# Altium schematic sheet Sch-QWA17_MCU.SchDoc(Sch-QWA17_MCU)
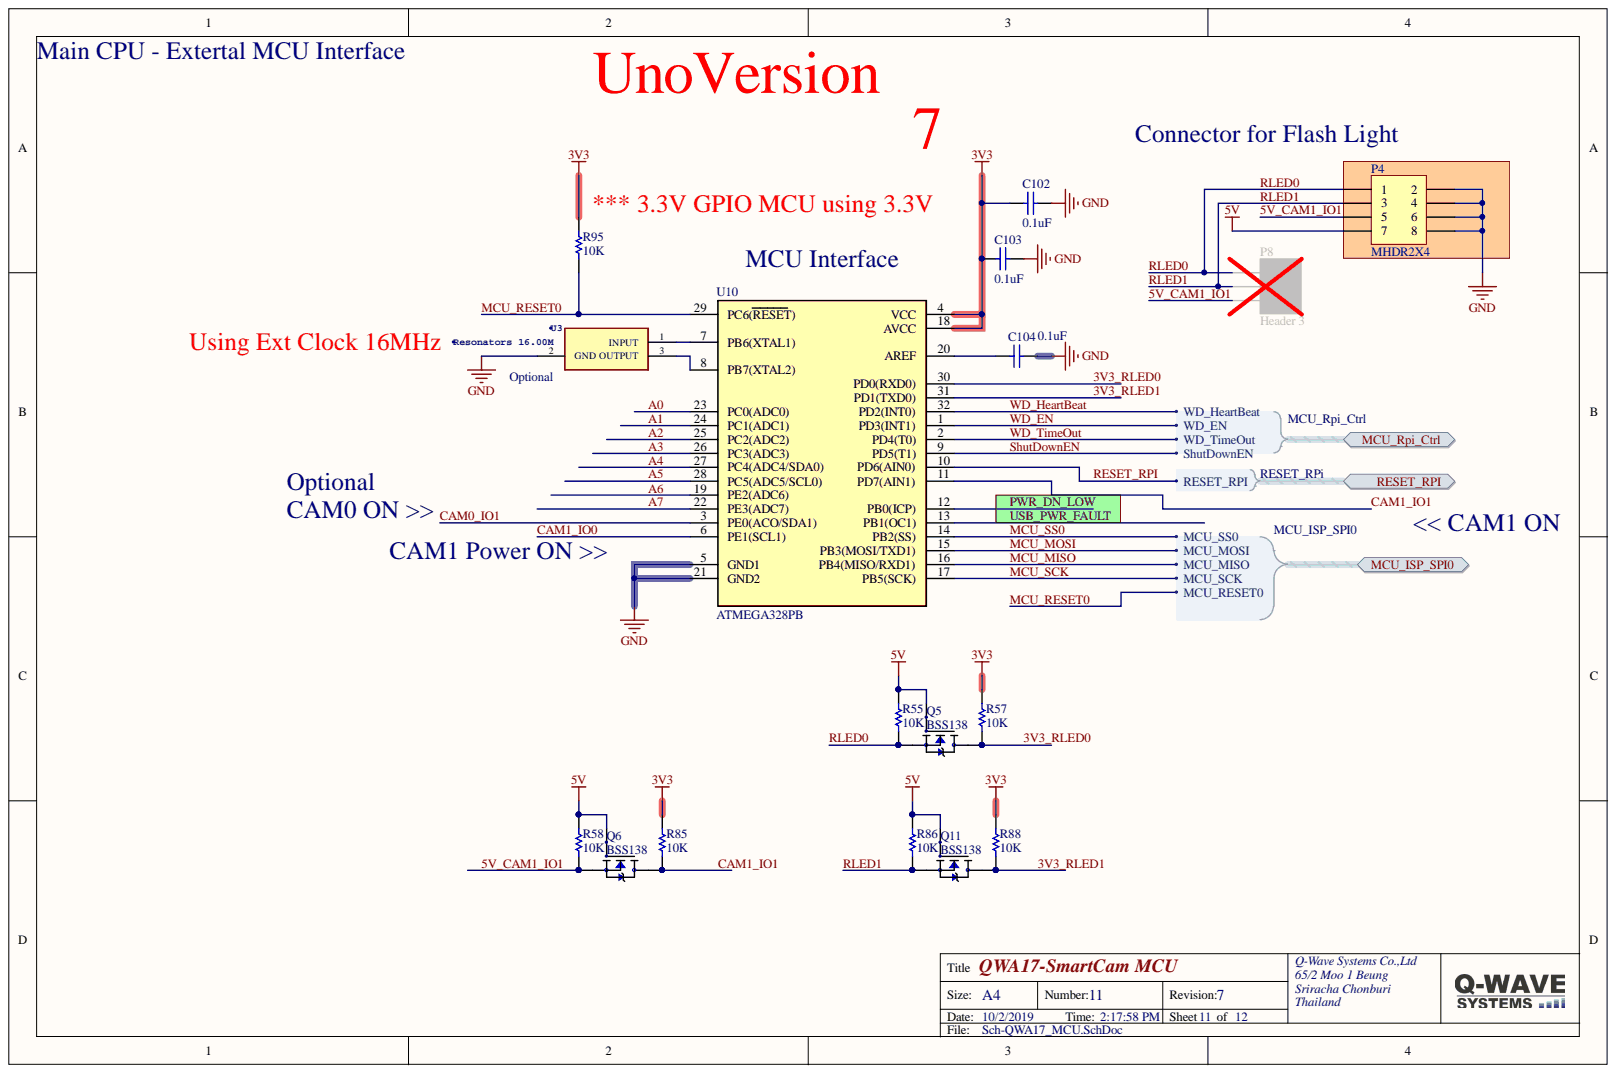
[diagram p0: Sch-QWA17_MCU.SchDoc]

## Parts
C102  0.1uF  [CAP_0603]
C103  0.1uF  [CAP_0603]
C104  0.1uF  [CAP_0603]
P4  MHDR2X4  [HDR2X4_2.54_180]
P8  Header 3  [FCI 06-381-3PIN]
Q5  BSS138  [SOT-23-3]
Q6  BSS138  [SOT-23-3]
Q11  BSS138  [SOT-23-3]
R55  10K  [RES_0603]
R57  10K  [RES_0603]
R58  10K  [RES_0603]
R85  10K  [RES_0603]
R86  10K  [RES_0603]
R88  10K  [RES_0603]
R95  10K  [RES_0603]
U3  Resonators 16.00M  [CSTNE16M0VH3C000R0]
U10  ATMEGA328PB  [LQFP_P0.8_32]

## Ports
MCU_ISP_SPI0, MCU_ISP_SPI0.MCU_MISO, MCU_ISP_SPI0.MCU_MOSI, MCU_ISP_SPI0.MCU_RESET0, MCU_ISP_SPI0.MCU_SCK, MCU_ISP_SPI0.MCU_SS0, MCU_RPI_CTRL, MCU_RPI_CTRL.SHUTDOWNEN, MCU_RPI_CTRL.WD_EN, MCU_RPI_CTRL.WD_HEARTBEAT, MCU_RPI_CTRL.WD_TIMEOUT, RESET_RPI, RESET_RPI.RESET_RPI

## Nets
3V3: C102.2 C103.2 R57.1 R85.1 R88.1 R95.1 U10.4(VCC) U10.18(AVCC)
5V: P4.7 Q5.1 Q6.1 Q11.1 R55.1 R58.1 R86.1
GND: C102.1 C103.1 C104.1 P4.2 P4.4 P4.6 P4.8 U3.2 U10.5(GND1) U10.21(GND2)
3V3_RLED0: Q5.3 R57.2 U10.30(PD0(RXD0))
3V3_RLED1: Q11.3 R88.2 U10.31(PD1(TXD0))
5V_CAM1_IO1: P4.5 P8.3 Q6.2 R58.2
A0: U10.23(PC0(ADC0))
A1: U10.24(PC1(ADC1))
A2: U10.25(PC2(ADC2))
A3: U10.26(PC3(ADC3))
A4: U10.27(PC4(ADC4/SDA0))
A5: U10.28(PC5(ADC5/SCL0))
A6: U10.19(PE2(ADC6))
A7: U10.22(PE3(ADC7))
CAM0_IO1: U10.3(PE0(ACO/SDA1))
CAM1_IO0: U10.6(PE1(SCL1))
CAM1_IO1: Q6.3 R85.2 U10.11(PD7(AIN1))
MCU_MISO: U10.16(PB4(MISO/RXD1)) ; port MCU_ISP_SPI0
MCU_MOSI: U10.15(PB3(MOSI/TXD1)) ; port MCU_ISP_SPI0
MCU_RESET0: R95.2 U10.29(PC6(RESET)) ; port MCU_ISP_SPI0
MCU_SCK: U10.17(PB5(SCK)) ; port MCU_ISP_SPI0
MCU_SS0: U10.14(PB2(SS)) ; port MCU_ISP_SPI0
PWR_DN_LOW: U10.12(PB0(ICP))
RESET_RPI: U10.10(PD6(AIN0)) ; port RESET_RPI
RLED0: P4.1 P8.1 Q5.2 R55.2
RLED1: P4.3 P8.2 Q11.2 R86.2
SHUTDOWNEN: U10.9(PD5(T1)) ; port MCU_RPI_CTRL
USB_PWR_FAULT: U10.13(PB1(OC1))
WD_EN: U10.1(PD3(INT1)) ; port MCU_RPI_CTRL
WD_HEARTBEAT: U10.32(PD2(INT0)) ; port MCU_RPI_CTRL
WD_TIMEOUT: U10.2(PD4(T0)) ; port MCU_RPI_CTRL
NetC104_2: C104.2 U10.20(AREF)
NetU3_1: U3.1 U10.7(PB6(XTAL1))
NetU3_3: U3.3 U10.8(PB7(XTAL2))

## Library data of the parts
C102-C104 = Cap Semi: 0.1µF ±5% 50V Ceramic Capacitor X7R 0603; MFG: KEMET; MFG P/N: C0603C104J5RACTU; Component Kind: Standard; Library Name: Miscellaneous Devices.IntLib; Simulation: CAP
P4 = MHDR2X4: Header, 4-Pin, Dual row; Component Kind: Standard; LatestRevisionDate: 17-Jul-2002; LatestRevisionNote: Re-released for DXP Platform.; Library Name: Miscellaneous Connectors.IntLib; Publisher: Altium Limited
P8 = Header 3: Header, 3-Pin; Component Kind: Standard; LatestRevisionDate: 17-Jul-2002; LatestRevisionNote: Re-released for DXP Platform.; Library Name: Miscellaneous Connectors.IntLib; Publisher: Altium Limited
Q5 Q6 Q11 = BSS138: N-Channel 50V 220mA (Ta) 360mW (Ta) Surface Mount SOT-23-3; MFG: ON Semiconductor; Availability: Unavailable; Component Kind: Standard; MFG P/N: BSS138; Package: SOT-23 Diodes Inc.; Price: 0.21 USD; Supplier1: ES; Supplier_Part_Number: 0016-0377-1; Supplier_Purchase_URL: https://www.es.co.th/detail.asp?Prod=001603771; Library Name: EspVIEW.IntLib
R55 R57 R58 R85 R86 R88 R95 = Res Semi: Resistor 0603; Component Kind: Standard; Library Name: Miscellaneous Devices.IntLib; Simulation: RES
U3 = CSTNE16M0VH3C000R0: Manufacturer_Name: Murata Electronics; GateName_1: G$1; Component Kind: Standard; Description: CSTNE16M0VH3C000R0; DeviceSetName: CSTNE16M0VH3C000R0; Height: 1mm; Library Name: CSTNE16M0VH3C000R0.IntLib; LibraryName: CSTNE16M0VH3C000R0; Manufacturer_Part_Number: CSTNE16M0VH3C000R0; Mouser_Part_Number: 81-CSTNE16M0VH3C000R; Mouser_Price-Stock: https://www.mouser.com/Search/Refine.aspx?Keyword=81-CSTNE16M0VH3C000R; SymbolName_1: CSTNE16M0VH3C000R0
U10 = ATMEGA328PB_32_TQFP: Component Kind: Standard; Library Name: Atmega328PB.SchLib
